# Altium schematic sheet CAN.SchDoc(CAN)
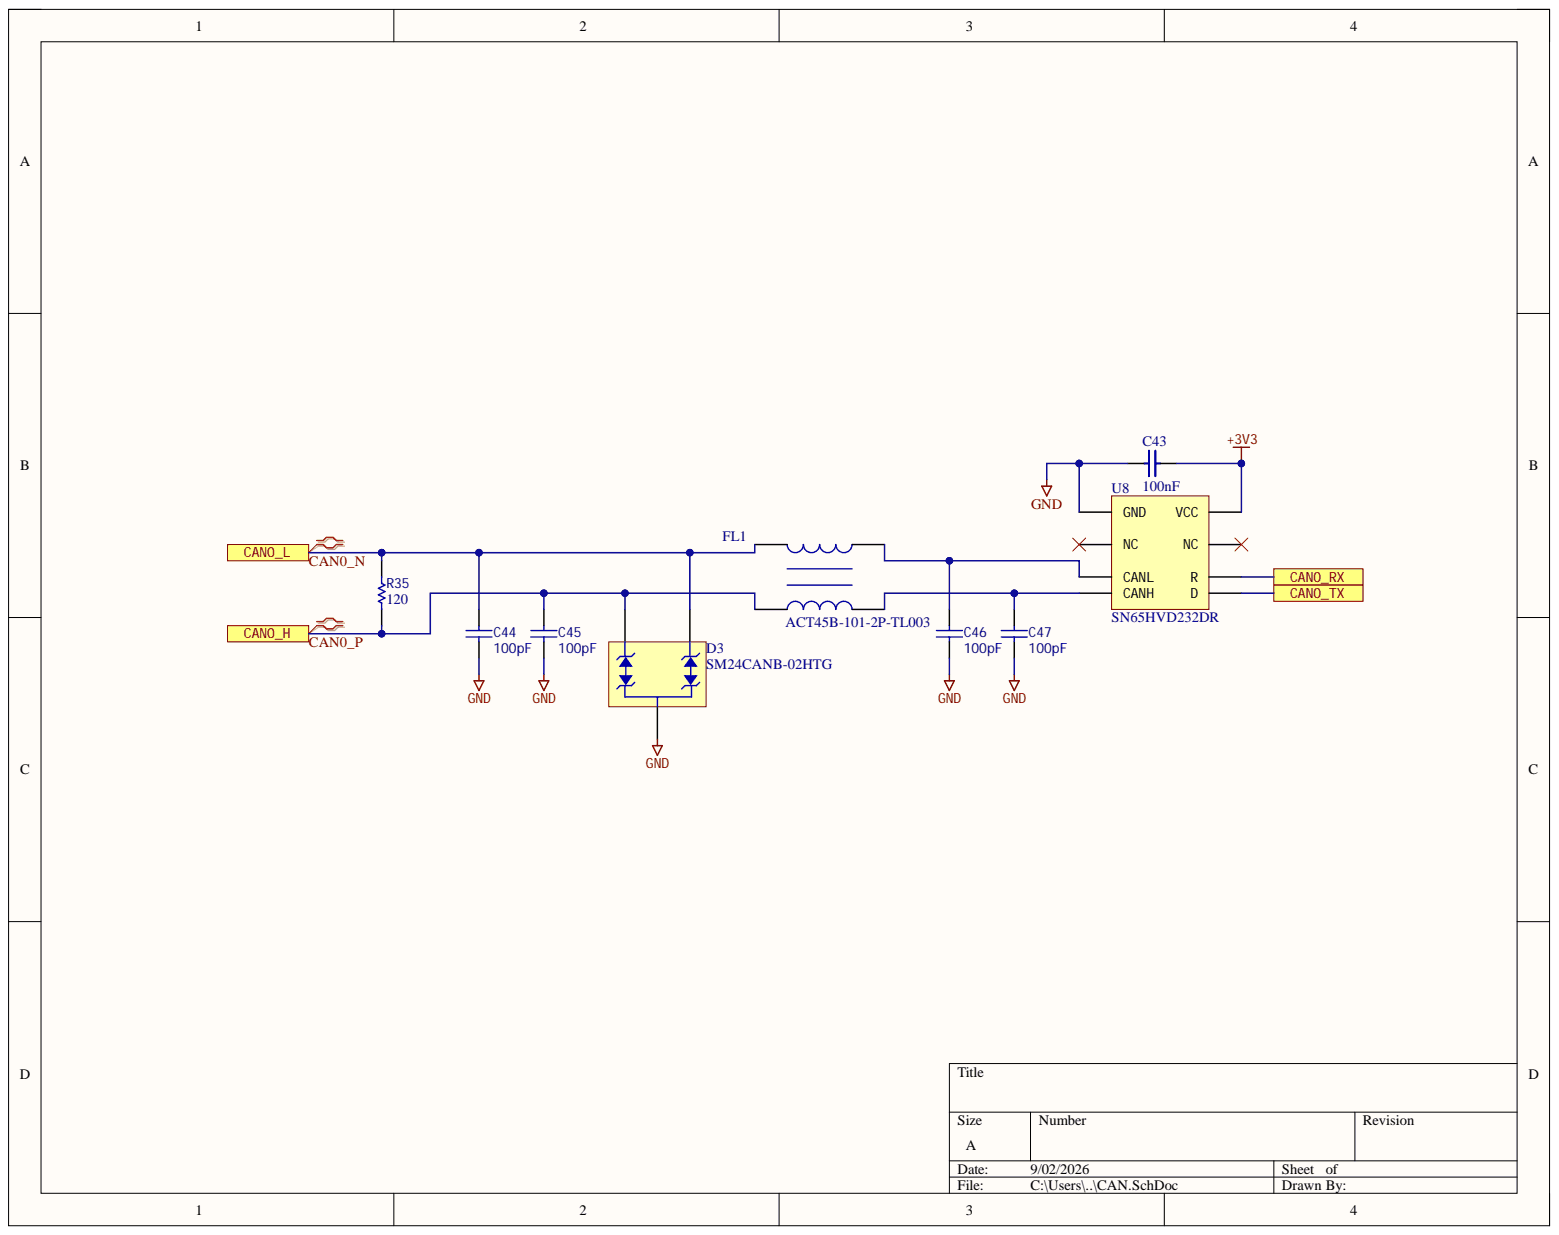
[diagram p0: CAN.SchDoc]

## Parts
C43  100nF  [C-0402]
C44  100pF  [C-0402]
C45  100pF  [C-0402]
C46  100pF  [C-0402]
C47  100pF  [C-0402]
D3  SM24CANB-02HTG  [SM24CANA02HTG]
FL1  ACT45B-101-2P-TL003  [FIL_ACT45B-101-2P-TL003]
R35  value 120  [R-0402]  (hidden comment: Resistor 120)
U8  SN65HVD232DR  [D0008A_L]

## Ports
CAN0_H, CAN0_L, CAN0_RX, CAN0_TX

## Nets
+3V3: C43.1 U8.3(VCC)
GND: C43.2 C44.1 C45.1 C46.1 C47.1 D3.3 U8.2(GND)
CAN0_N: C44.2 D3.1 FL1.1 R35.2 ; port CAN0_L
CAN0_P: C45.2 D3.2 FL1.2 R35.1 ; port CAN0_H
CAN0_RX: U8.4(R) ; port CAN0_RX
CAN0_TX: U8.1(D) ; port CAN0_TX
NetC46_2: C46.2 FL1.4 U8.6(CANL)
NetC47_2: C47.2 FL1.3 U8.7(CANH)

Not connected: U8 5(NC) 8(NC)

## Library data of the parts
C43 = CMP-001-00203-1: 0.1 µF ±10% 50V Ceramic Capacitor X7R 0402 (1005 Metric); Manufacturer: Murata; Manufacturer PN: GCM155R71H104KE02J; Voltage Rating: 50; Component Kind: Standard; ComponentLink1Description: Datasheet; ComponentLink1URL: https://www.mouser.com/datasheet/3/508/1/KEM_C1002_X7R_SMD.pdf; Library Name: BLDC_Symbols.SCHLIB; Package: 0402; Supplier 1: Digikey; Supplier Part Number 1: GCM155R71H104KE02J; Tolerance: 10%; Type: Capacitor X7R; Value: 100nF
C44-C47 = CMP-001-00084-5: 100 pF ±5% 200V Ceramic Capacitor C0G, NP0 0402 (1608 Metric); Manufacturer: KEMET; Manufacturer PN: C0402C101J5GACTU; Type: Capacitor C0G/NP0; Voltage Rating: 50V; Component Kind: Standard; ComponentLink1Description: Datasheet; ComponentLink1URL: https://www.vishay.com/docs/45258/vjhifreqseries.pdf; Library Name: BLDC_Symbols.SchLib; Package: 0402; Supplier 1: Digikey; Supplier Part Number 1: 399-C0402C101J5GACTUCT-ND; Tolerance: 5%; Value: 100pF
D3 = CMP-004-00048-1: TVS Diode (Bi-directional); Manufacturer: Littelfuse Inc.; Current: 10A; Power: 500W; Voltage Rating: 50V; Component Kind: Standard; ComponentLink1Description: Datasheet; ComponentLink1URL: https://www.littelfuse.com/assetdocs/littelfuse_tvs_diode_array_sm24canb_datasheet.pdf?assetguid=09dc84a6-1ff8-409f-a80f-5e92aedec8fe; Library Name: BLDC_Symbols.SchLib; Manufacturer PN: SM24CANB-02HTG; Package: SOT; Supplier 1: Digikey; Supplier Part Number 1: F6707CT-ND; Type: TVS Diode; Value: SM24CANB-02HTG
FL1 = CMP-035-000000-6: 100ÂµH @ 100kHz 2 Line Common Mode Choke Surface Mount 5.8 kOhms @ 10MHz 150mA DCR 2Ohm; Manufacturer: TDK; Voltage Rating: 50V; Component Kind: Standard; ComponentLink1Description: Datasheet; ComponentLink1URL: https://product.tdk.com/en/system/files/dam/doc/product/emc/emc/cmf_cmc/catalog/cmf_automotive_signal_act45b_en.pdf; Current: 150mA; Library Name: BLDC_Symbols.SchLib; Manufacturer PN: ACT45B-101-2P-TL003; Package: 1812; Supplier 1: Digikey; Supplier Part Number 1: 445-3959-1-ND; Type: Common-Mode Choke; Value: ACT45B-101-2P-TL003
R35 = Resistor 120: 120 Ohms ±5% 0.063W, 1/16W Chip Resistor 0402 (1005 Metric) Moisture Resistant Thick Film; Manufacturer: Panasonic; Manufacturer PN: ERA-2AEB121X; Power: 0.0625; Tolerance: 0.01%; Component Kind: Standard; ComponentLink1Description: Datasheet; Library Name: BLDC_Symbols.SCHLIB; Package: 0402; Supplier 1: Digikey; Supplier Part Number 1: P120DCCT-ND; Type: Resistor
U8 = CMP-216-000000-4: 3.3 V Can Transceiver, 17 mA, -40 to 85 degC, 8-pin SOIC (D), Green (RoHS & no Sb/Br); Manufacturer: Texas Instruments; Voltage Rating: 3.6V; Component Kind: Standard; ComponentLink1Description: Datasheet; ComponentLink1URL: https://www.ti.com/general/docs/suppproductinfo.tsp?distId=10&gotoUrl=https%3A%2F%2Fwww.ti.com%2Flit%2Fgpn%2Fsn65hvd230; Library Name: BLDC_Symbols.SchLib; Manufacturer PN: SN65HVD232DR; Package: SOIC; Supplier 1: Digikey; Supplier Part Number 1: 296-26344-2-ND; Type: CAN Transceiver; Value: SN65HVD232DR
